# Altium schematic sheet SSM2044Filter.SchDoc
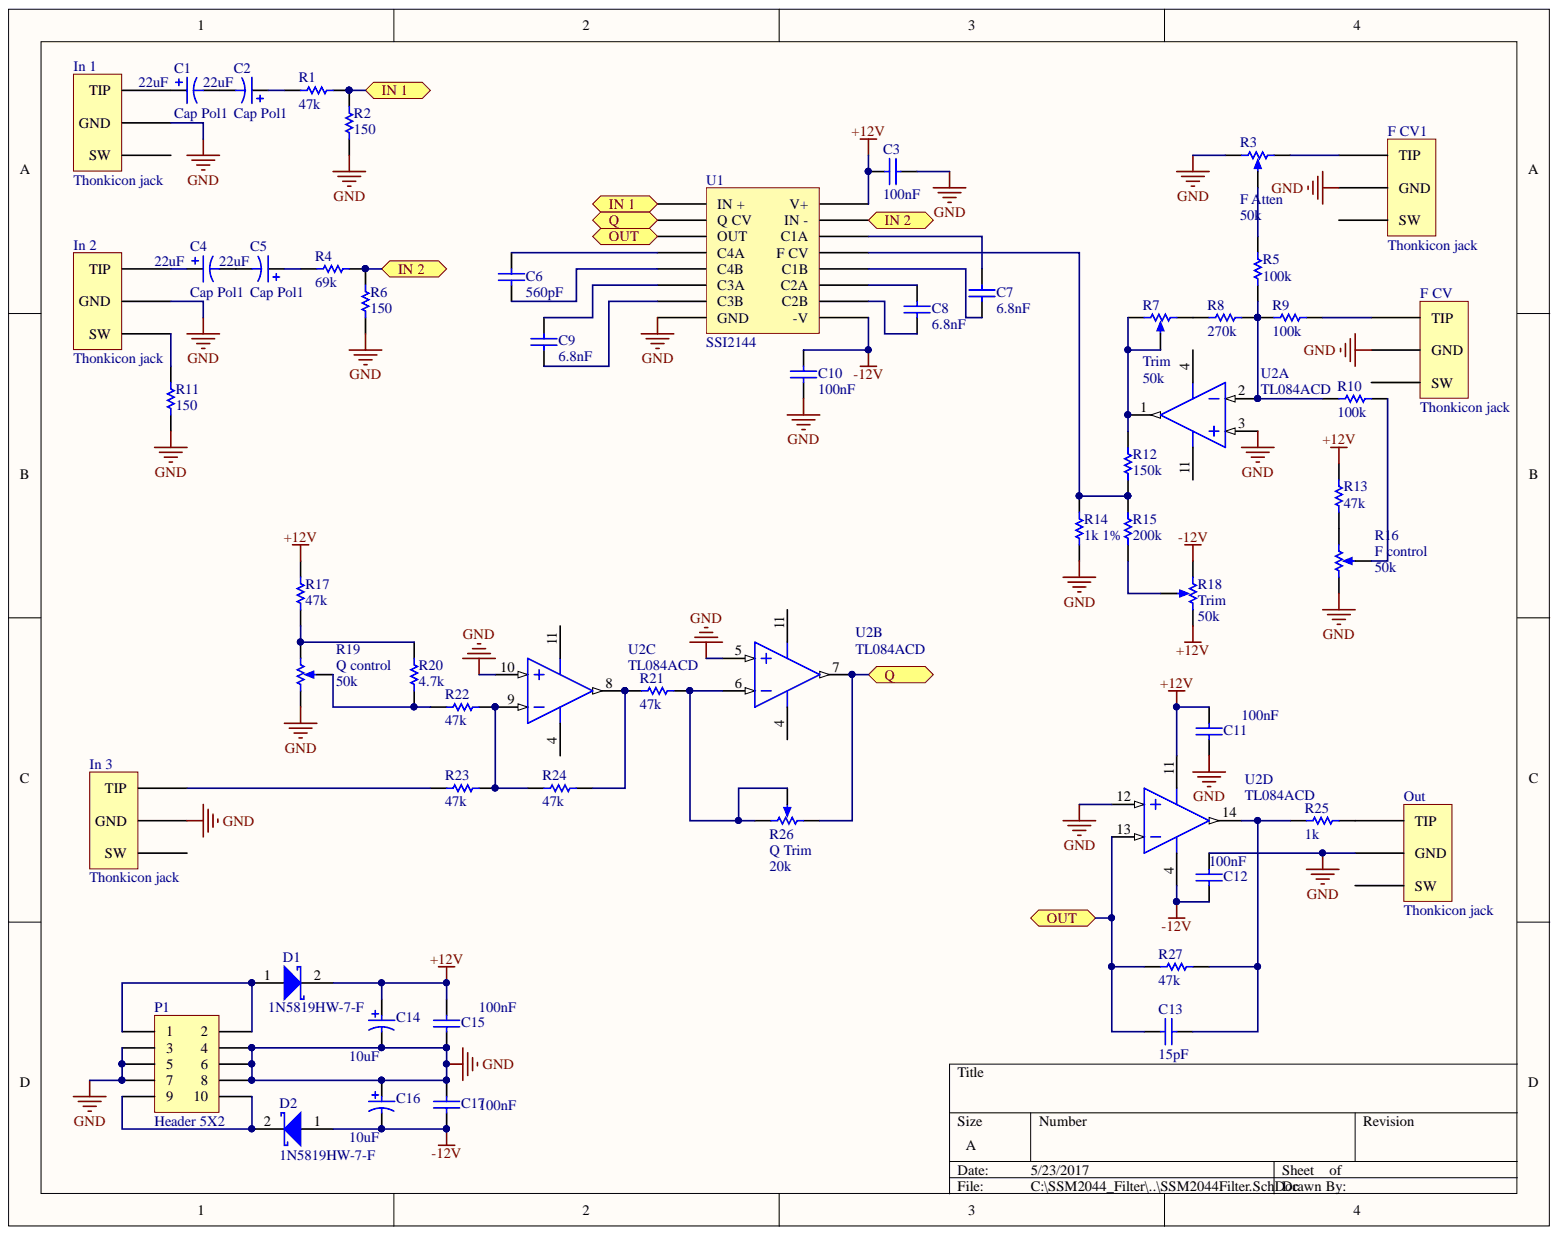
[diagram p0: SSM2044Filter.SchDoc]

## Parts
C1  Cap Pol1  value 22uF  [RB7.6-15]
C2  Cap Pol1  value 22uF  [RB7.6-15]
C3  100nF  [CAPC0603(1608)100_M]
C4  Cap Pol1  value 22uF  [RB7.6-15]
C5  Cap Pol1  value 22uF  [RB7.6-15]
C6  560pF  [CAPC0603(1608)100_M]
C7  6.8nF  [CAPC0603(1608)100_M]
C8  6.8nF  [CAPC0603(1608)100_M]
C9  6.8nF  [CAPC0603(1608)100_M]
C10  100nF  [CAPC0603(1608)100_M]
C11  100nF  [CAPC0603(1608)100_M]
C12  100nF  [CAPC0603(1608)100_M]
C13  15pF  [CAPC0603(1608)100_M]
C14  10uF  [NIC-CAPA2-4X5.8_V]
C15  100nF  [CAPC0603(1608)100_M]
C16  10uF  [NIC-CAPA2-4X5.8_V]
C17  100nF  [CAPC0603(1608)100_M]
D1  1N5819HW-7-F  [DIOD-SOD-123-2_V]
D2  1N5819HW-7-F  [DIOD-SOD-123-2_V]
F_CV  Thonkicon jack  [Thonkiconn Pot PJ301M]
F_CV1  Thonkicon jack  [Thonkiconn Pot PJ301M]
In_1  Thonkicon jack  [Thonkiconn Pot PJ301M]
In_2  Thonkicon jack  [Thonkiconn Pot PJ301M]
In_3  Thonkicon jack  [Thonkiconn Pot PJ301M]
Out  Thonkicon jack  [Thonkiconn Pot PJ301M]
P1  Header 5X2  [HDR2X5]
R1  47k  [RESC0603(1608)_M]
R2  150  [RESC0603(1608)_M]
R3  F Atten  value 50k  [VR5]
R4  69k  [RESC0603(1608)_M]
R5  100k  [RESC0603(1608)_M]
R6  150  [RESC0603(1608)_M]
R7  Trim  value 50k  [VR5]
R8  270k  [RESC0603(1608)_M]
R9  100k  [RESC0603(1608)_M]
R10  100k  [RESC0603(1608)_M]
R11  150  [RESC0603(1608)_M]
R12  150k  [RESC0603(1608)_M]
R13  47k  [RESC0603(1608)_M]
R14  1k 1%  [RESC0603(1608)_M]
R15  200k  [RESC0603(1608)_M]
R16  F control  value 50k  [VR5]
R17  47k  [RESC0603(1608)_M]
R18  Trim  value 50k  [VR5]
R19  Q control  value 50k  [VR5]
R20  4.7k  [RESC0603(1608)_M]
R21  47k  [RESC0603(1608)_M]
R22  47k  [RESC0603(1608)_M]
R23  47k  [RESC0603(1608)_M]
R24  47k  [RESC0603(1608)_M]
R25  1k  [RESC0603(1608)_M]
R26  Q Trim  value 20k  [VR5]
R27  47k  [RESC0603(1608)_M]
U1  SSI2144
U2  TL084ACD  [D0014A_L]  (parts A,B,C,D)

## Ports
IN 1, IN 2, OUT, Q

## Nets
+12V: C3.1 C11.1 C14.1 C15.1 D1.2 R13.1 R17.2 R18.2 U1.16(V+) U2.11
-12V: C10.2 C12.2 C16.2 C17.2 D2.1 R18.1 U1.9(-V) U2.4
GND: C3.2 C10.1 C11.2 C12.1 C14.2 C15.2 C16.1 C17.1 F_CV.1 F_CV1.1 In_1.1 In_2.1 In_3.1 Out.1 P1.3 P1.4 P1.5 P1.6 P1.7 P1.8 R2.2 R3.1 R6.2 R11.2 R14.2 R16.1 R19.1 U1.8(GND) U2.3 U2.5 U2.10 U2.12
IN 1: R1.1 R2.1 U1.1(IN_+) ; port IN 1
IN 2: R4.1 R6.1 U1.15(IN_-) ; port IN 2
OUT: C13.2 R27.2 U1.3(OUT) U2.13 ; port OUT
Q: R26.1 U1.2(Q_CV) U2.7 ; port Q
NetC1_1: C1.1 In_1.3
NetC1_2: C1.2 C2.2
NetC2_1: C2.1 R1.2
NetC4_1: C4.1 In_2.3
NetC4_2: C4.2 C5.2
NetC5_1: C5.1 R4.2
NetC6_1: C6.1 U1.5(C4B)
NetC6_2: C6.2 U1.4(C4A)
NetC7_1: C7.1 U1.11(C1B)
NetC7_2: C7.2 U1.14(C1A)
NetC8_1: C8.1 U1.10
NetC8_2: C8.2 U1.10
NetC9_1: C9.1 U1.7(C3B)
NetC9_2: C9.2 U1.6(C3A)
NetC13_1: C13.1 R25.2 R27.1 U2.14
NetD1_1: D1.1 P1.1 P1.2
NetD2_2: D2.2 P1.9 P1.10
NetF CV1_3: F_CV1.3 R3.2
NetF CV_3: F_CV.3 R9.2
NetIn 2_2: In_2.2 R11.1
NetIn 3_3: In_3.3 R23.2
NetOut_3: Out.3 R25.1
NetR3_3: R3.3 R5.2
NetR5_1: R5.1 R8.2 R9.1 R10.1 U2.2
NetR7_1: R7.1 R7.3 R12.1 U2.1
NetR7_2: R7.2 R8.1
NetR10_2: R10.2 R16.3
NetR12_2: R12.2 R14.1 R15.1 U1.12(F_CV)
NetR13_2: R13.2 R16.2
NetR15_2: R15.2 R18.3
NetR17_1: R17.1 R19.2 R20.2
NetR19_3: R19.3 R20.1 R22.2
NetR21_1: R21.1 R26.2 R26.3 U2.6
NetR21_2: R21.2 R24.1 U2.8
NetR22_1: R22.1 R23.1 R24.2 U2.9

Not connected: F_CV 2; F_CV1 2; In_1 2; In_3 2; Out 2

## Library data of the parts
C1 C2 C4 C5 = Cap Pol1: Polarized Capacitor (Radial); Component Kind: Standard; LatestRevisionDate: 17-Jul-2002; LatestRevisionNote: Re-released for DXP Platform.; Library Name: Miscellaneous Devices.IntLib; PackageDescription: Polarized Capacitor (Radial); 2 Leads; PackageReference: RB7.6-15; Published: 8-Jun-2000; Signal Integrity: Cap Pol; Simulation: CAP; Publisher: Altium Limited
C3 C10-C12 C15 C17 = CMP-1035-00002-1: CAP; Mfr: AVX; Mfr_Pn: MM035C104KCZ2A; Component Kind: Standard; Distributor: Mouser; Distributor_Pn: 581-MM035C104KCZ2A; Library Name: Altium Content Vault
C6-C9 C13 = CMP-1035-00002-1: CAP; Mfr: AVX; Component Kind: Standard; Distributor: Mouser; Library Name: Altium Content Vault
C14 C16 = CMP-0687-00016-1: Aluminum Electrolytic Capacitor, UD Series, Low Impedance, 10 uF, 25 V, + / - 20%, -55 to 105 degC, Chip Type, 4 x 5.8 mm D x L, Pb-Free, Reel; Mfr: Nichicon; Mfr_Pn: UUD1E100MCL1GS; Component Kind: Standard; Distributor: Mouser; Distributor_Pn: 647-UUD1E100MCL; Library Name: Altium Content Vault
D1 D2 = CMP-0303-00003-1: 1.0 A Surface Mount Schottky Barrier Rectifier, 40 V, -65 to 125 degC, 2-Pin SOD-123 Package, RoHS, Tape and Reel; Mfr: Diodes Inc.; Component Kind: Standard; Distributor: Mouser; Distributor_Pn: 621-1N5819HW-F; Library Name: Altium Content Vault; Mfr_Pn: 1N5819HW-7-F
P1 = Header 5X2: Header, 5-Pin, Dual row; Ditributor_Pn: 855-M20-9980545; Component Kind: Standard; LatestRevisionDate: 17-Jul-2002; LatestRevisionNote: Re-released for DXP Platform.; Library Name: Miscellaneous Connectors.IntLib; Signal Integrity: Connector; Publisher: Altium Limited
R1 R2 R4-R6 R8-R15 R17 R20-R25 R27 = CMP-1012-00002-1: Res; Mfr: Susumu; Case-EIA: 0603; Tolerance: 5 %; Component Kind: Standard; Distributor: Mouser; Library Name: Altium Content Vault
  R1 R13 R17 R21-R24 R27: Value: 47k
  R2 R6 R11: Value: 150
  R4: Value: 69k
  R5 R9 R10: Value: 100k
  R8: Value: 270k
  R12: Value: 150k
  R14: Value: 1k 1%
  R15: Value: 200k
  R20: Value: 4.7k
  R25: Value: 1k
R3 R7 = RPot: Potentiometer; Set Position: 0.5; Sim Note: Enter the Resistance value and the set point into parameter fields.; Value: 50k; Component Kind: Standard; LatestRevisionDate: 17-Jul-2002; LatestRevisionNote: Re-released for DXP Platform.; Library Name: Miscellaneous Devices.IntLib; PackageDescription: Variable Resistor, Thru-Hole; 3 Leads; PackageReference: VR5; Published: 8-Jun-2000; Simulation: POT; Publisher: Altium Limited
R16 R18 R19 R26 = RPot: Potentiometer; Set Position: 0.5; Sim Note: Enter the Resistance value and the set point into parameter fields.; Component Kind: Standard; LatestRevisionDate: 17-Jul-2002; LatestRevisionNote: Re-released for DXP Platform.; Library Name: Miscellaneous Devices.IntLib; PackageDescription: Variable Resistor, Thru-Hole; 3 Leads; PackageReference: VR5; Published: 8-Jun-2000; Simulation: POT; Publisher: Altium Limited
U2 = CMP-0017-00182-2: JFET-Input Operational Amplifier, 7 to 36 V, 0 to 70 degC, 14-pin SOIC (D14), Green (RoHS & no Sb/Br); Manufacturer: Texas Instruments; CMRR(Min)(dB): 75; GBW(Typ)(MHz): 3; IIB(Max)(pA): 200; Iq per channel(Max)(mA): 2.8; Number of Channels: 4; Offset Drift(Typ)(uV/C): 18; Rating: Catalog; Slew Rate(Typ)(V/us): 13; Sub Family: General Purpose Amplifier, High Supply Voltage Amplifier (>=30V); Total Supply Voltage(Max)(+5V is 5, +/-5V is 10): 36; Total Supply Voltage(Min)(+5V is 5, +/-5V is 10): 7; Vio (25C)(Max)(mV): 6; Code_JEDEC: MS-012; Component Kind: Standard; ComponentLink1Description: Manufacturer URL; ComponentLink1URL: http://www.ti.com/; ComponentLink2Description: Datasheet; ComponentLink2URL: http://www.ti.com/lit/ds/symlink/tl084a.pdf; ComponentLink3Description: Package Specification; ComponentLink3URL: http://www.ti.com/lit/ml/mpds177g/mpds177g.pdf; DatasheetVersion: SLOS081G; Eco-Plan: Green (RoHS & no Sb/Br); Library Name: Altium Content Vault; Mounting Technology: Surface Mount; PackageDescription: 14-Pin Plastic Small Outline, Body 8.65 x 3.90 mm, Pitch 1.27 mm; PackageReference: D0014A; PackageVersion: 4040047-5/M, 06/2011; PartNumber: TL084ACD; Vn at 1kHz(Typ)(nV/rtHz): 18
F_CV F_CV1 In_1-In_3 Out = Thonkicon jack: Component Kind: Standard; Library Name: EurrackParts.SchLib
U1 = SSI2144: Component Kind: Standard; Library Name: EurorackParts.SchLib
